# Altium schematic sheet ROBOT DE GUERRA.SchDoc
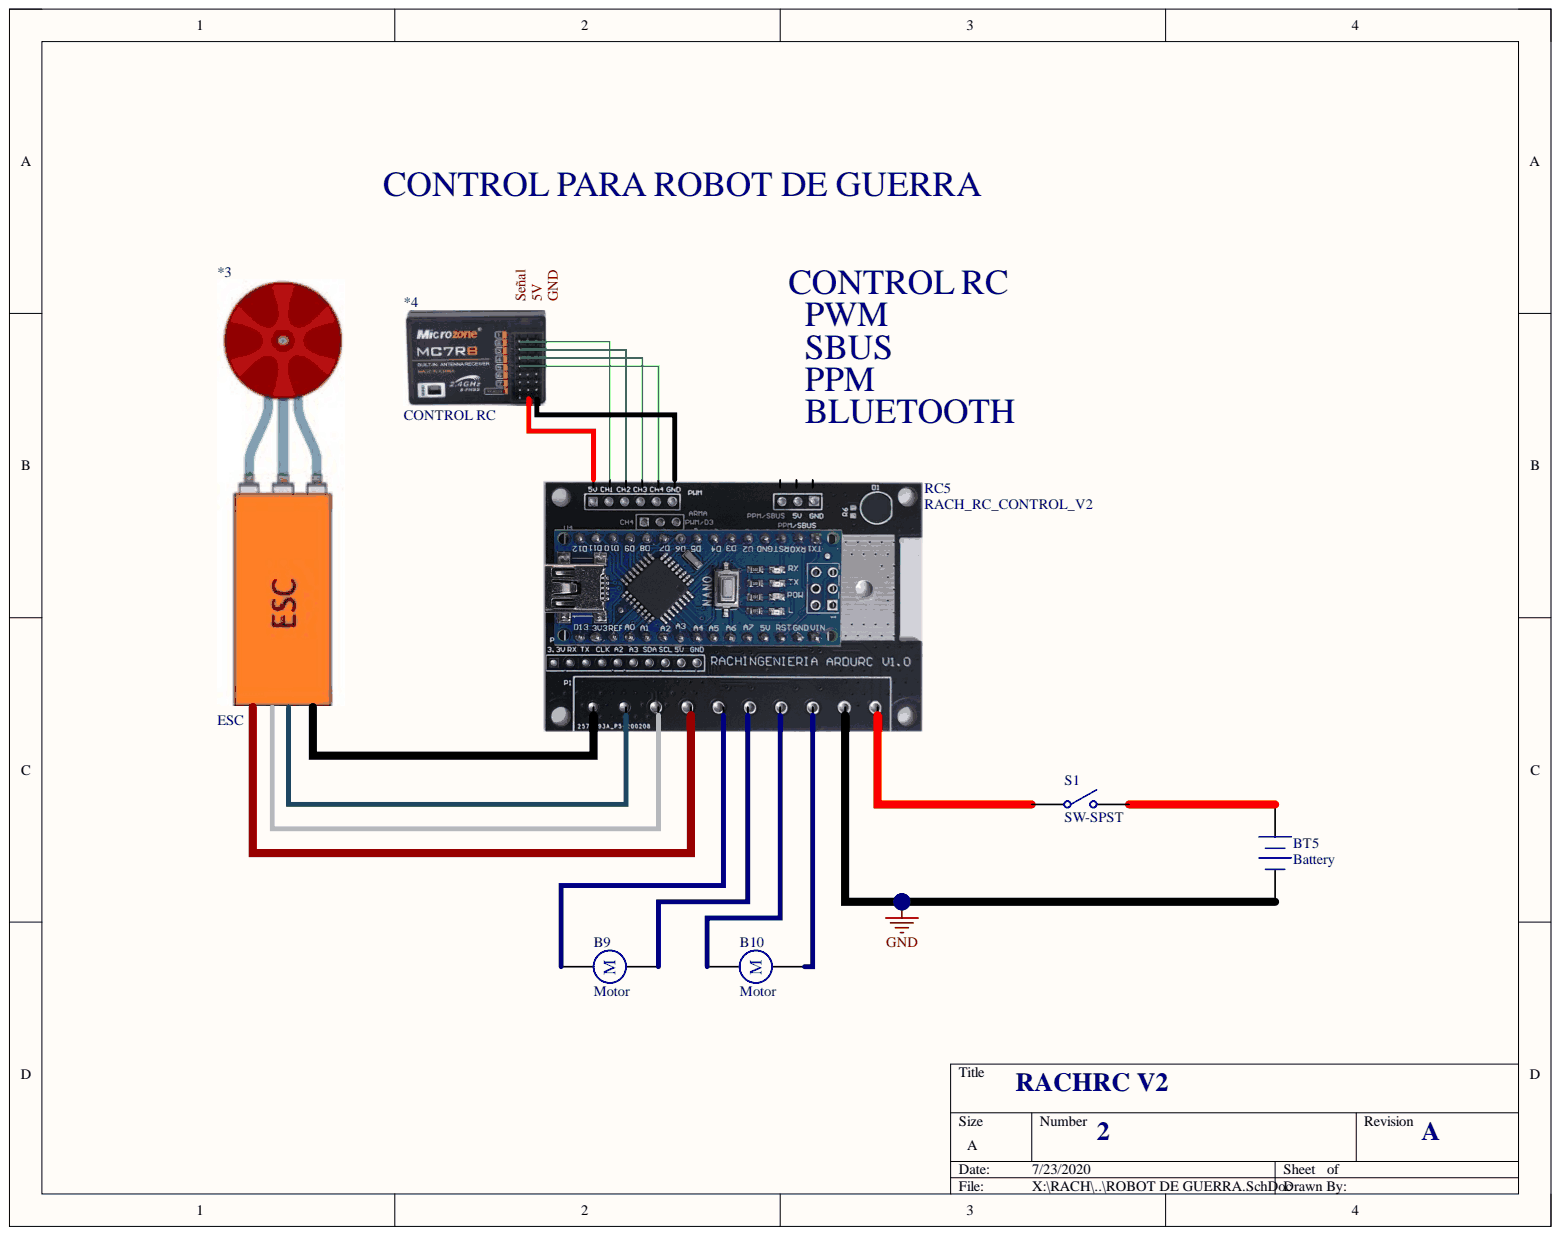
[diagram p0: ROBOT DE GUERRA.SchDoc]

## Parts
*3  ESC
*4  CONTROL RC
B9  Motor  [RB5-10.5]
B10  Motor  [RB5-10.5]
BT5  Battery  [BAT-2]
RC5  RACH_RC_CONTROL_V2
S1  SW-SPST  [SPST-2]

## Nets
GND: BT5.2 RC5.19
Net*3_25: *3.25 RC5.12
Net*3_27: *3.27 RC5.13
Net*3_28: *3.28 RC5.14
Net*3_29: *3.29 RC5.11
Net*4_1: *4.1 RC5.3
Net*4_4: *4.4 RC5.4
Net*4_7: *4.7 RC5.5
Net*4_10: *4.10 RC5.6
Net*4_23: *4.23 RC5.2
Net*4_24: *4.24 RC5.7
NetB9_1: B9.1 RC5.15
NetB9_2: B9.2 RC5.16
NetB10_1: B10.1 RC5.17
NetB10_2: B10.2 RC5.18
NetBT5_1: BT5.1 S1.2
NetRC5_20: RC5.20 S1.1
5V:
Señal:

Not connected: *3 26; *4 13 16 19 22; RC5 8 9 10

## Library data of the parts
B9 B10 = Motor: Motor, General Kind; Component Kind: Standard; LatestRevisionDate: 17-Jul-2002; LatestRevisionNote: Re-released for DXP Platform.; Library Name: Miscellaneous Devices.IntLib; PackageDescription: Capacitor (Radial); 2 Leads; PackageReference: RB5-10.5; Published: 24-Mar-1999; Publisher: Altium Limited
BT5 = Battery: Multicell Battery; Component Kind: Standard; LatestRevisionDate: 17-Jul-2002; LatestRevisionNote: Re-released for DXP Platform.; Library Name: Miscellaneous Devices.IntLib; PackageDescription: Battery; 2 Leads; PackageReference: BAT-2; Published: 24-Mar-1999; Publisher: Altium Limited
S1 = SW-SPST: Single-Pole, Single-Throw Switch; Component Kind: Standard; LatestRevisionDate: 17-Jul-2002; LatestRevisionNote: Re-released for DXP Platform.; Library Name: Miscellaneous Devices.IntLib; PackageDescription: Switch; 2 Leads; PackageReference: SPST-2; Published: 24-Mar-1999; Publisher: Altium Limited
*3 = ESC: Component Kind: Standard; Library Name: Componentes.SchLib
*4 = CONTROL RC: Component Kind: Standard; Library Name: Componentes.SchLib
RC5 = RACH_RC_CONTROL_V2: Component Kind: Standard; Library Name: Componentes.SchLib
